# Altium schematic sheet RAMPS_TMC.SchDoc(RAMPS_TMC)
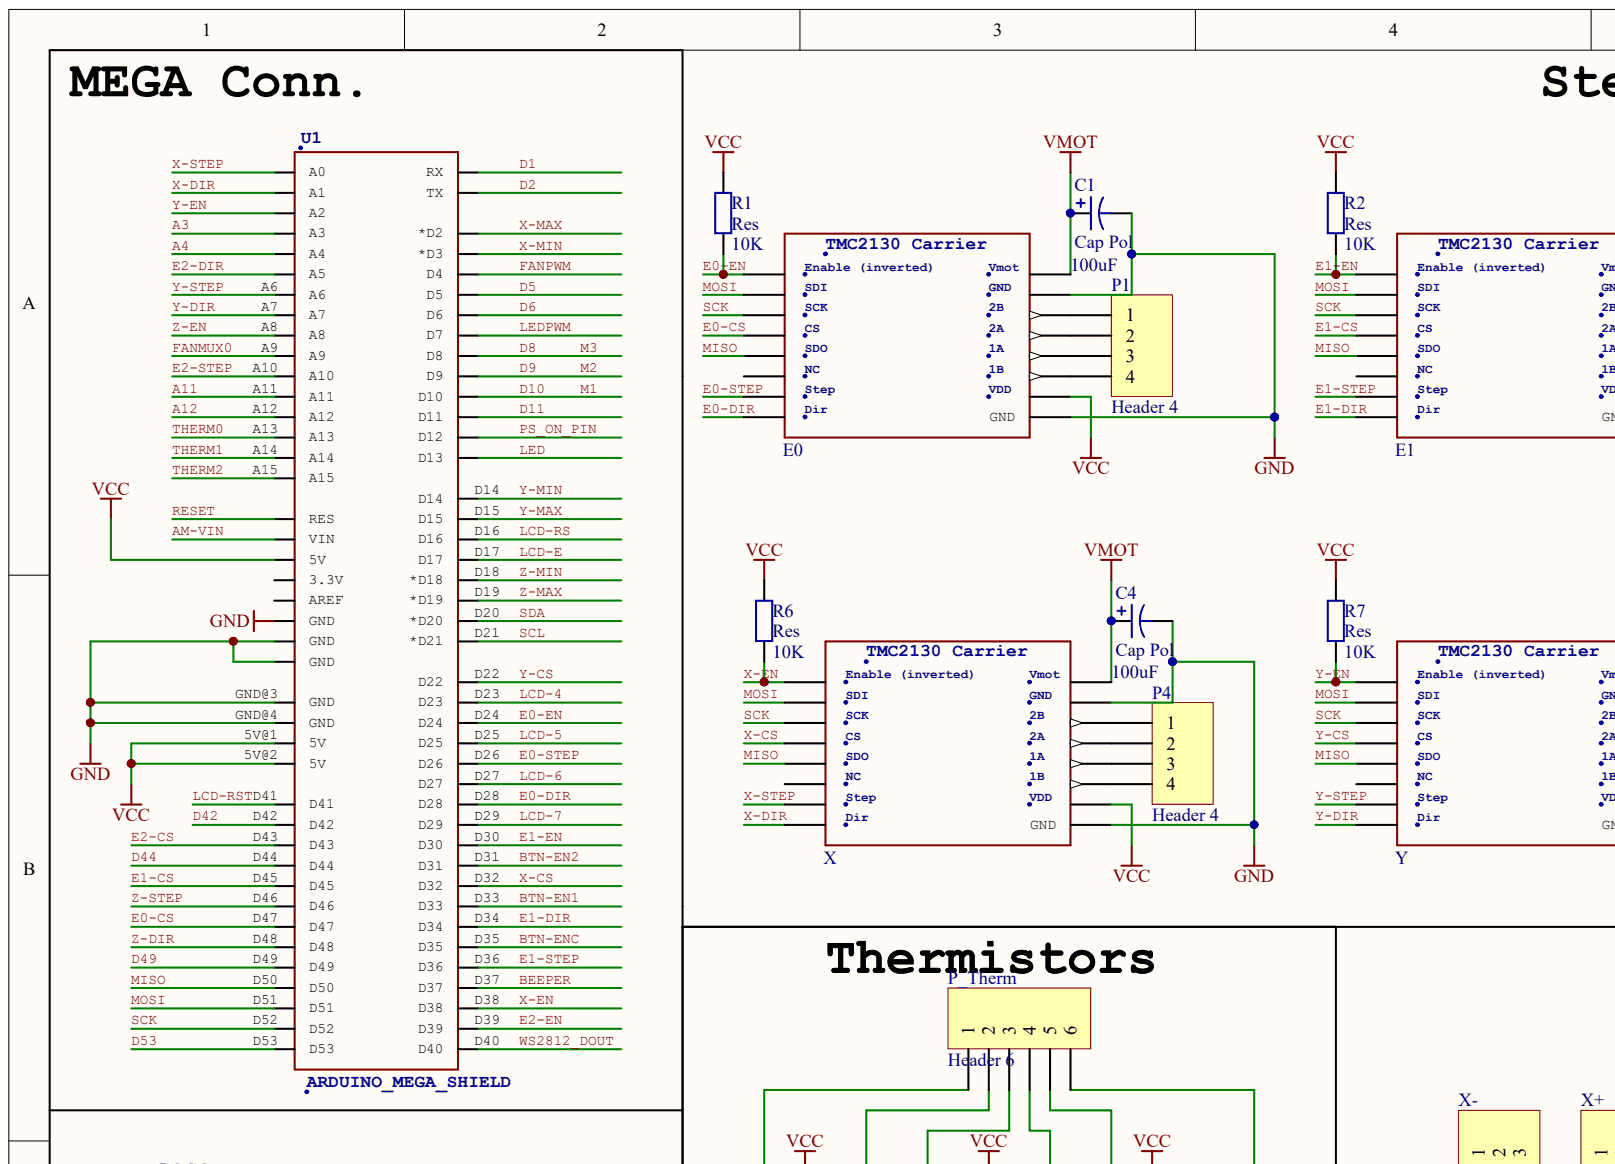
[diagram p0: RAMPS_TMC.SchDoc, top left]
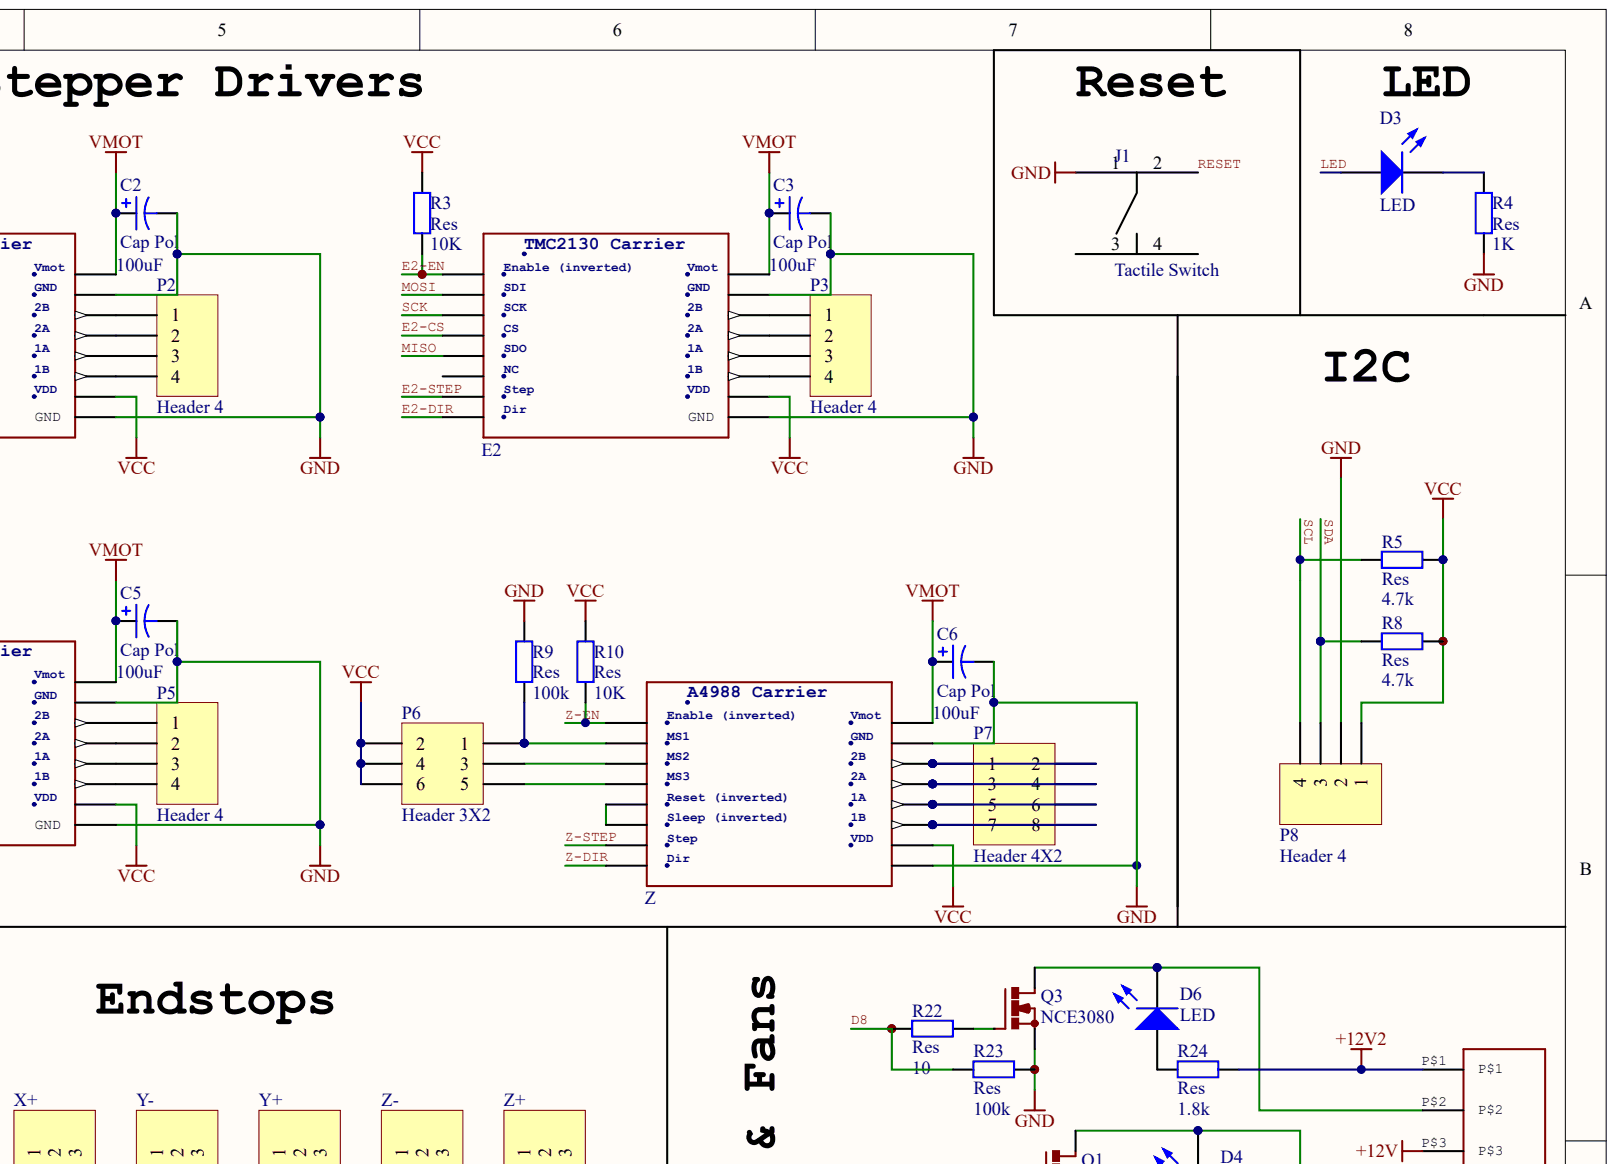
[diagram p1: RAMPS_TMC.SchDoc, top right]
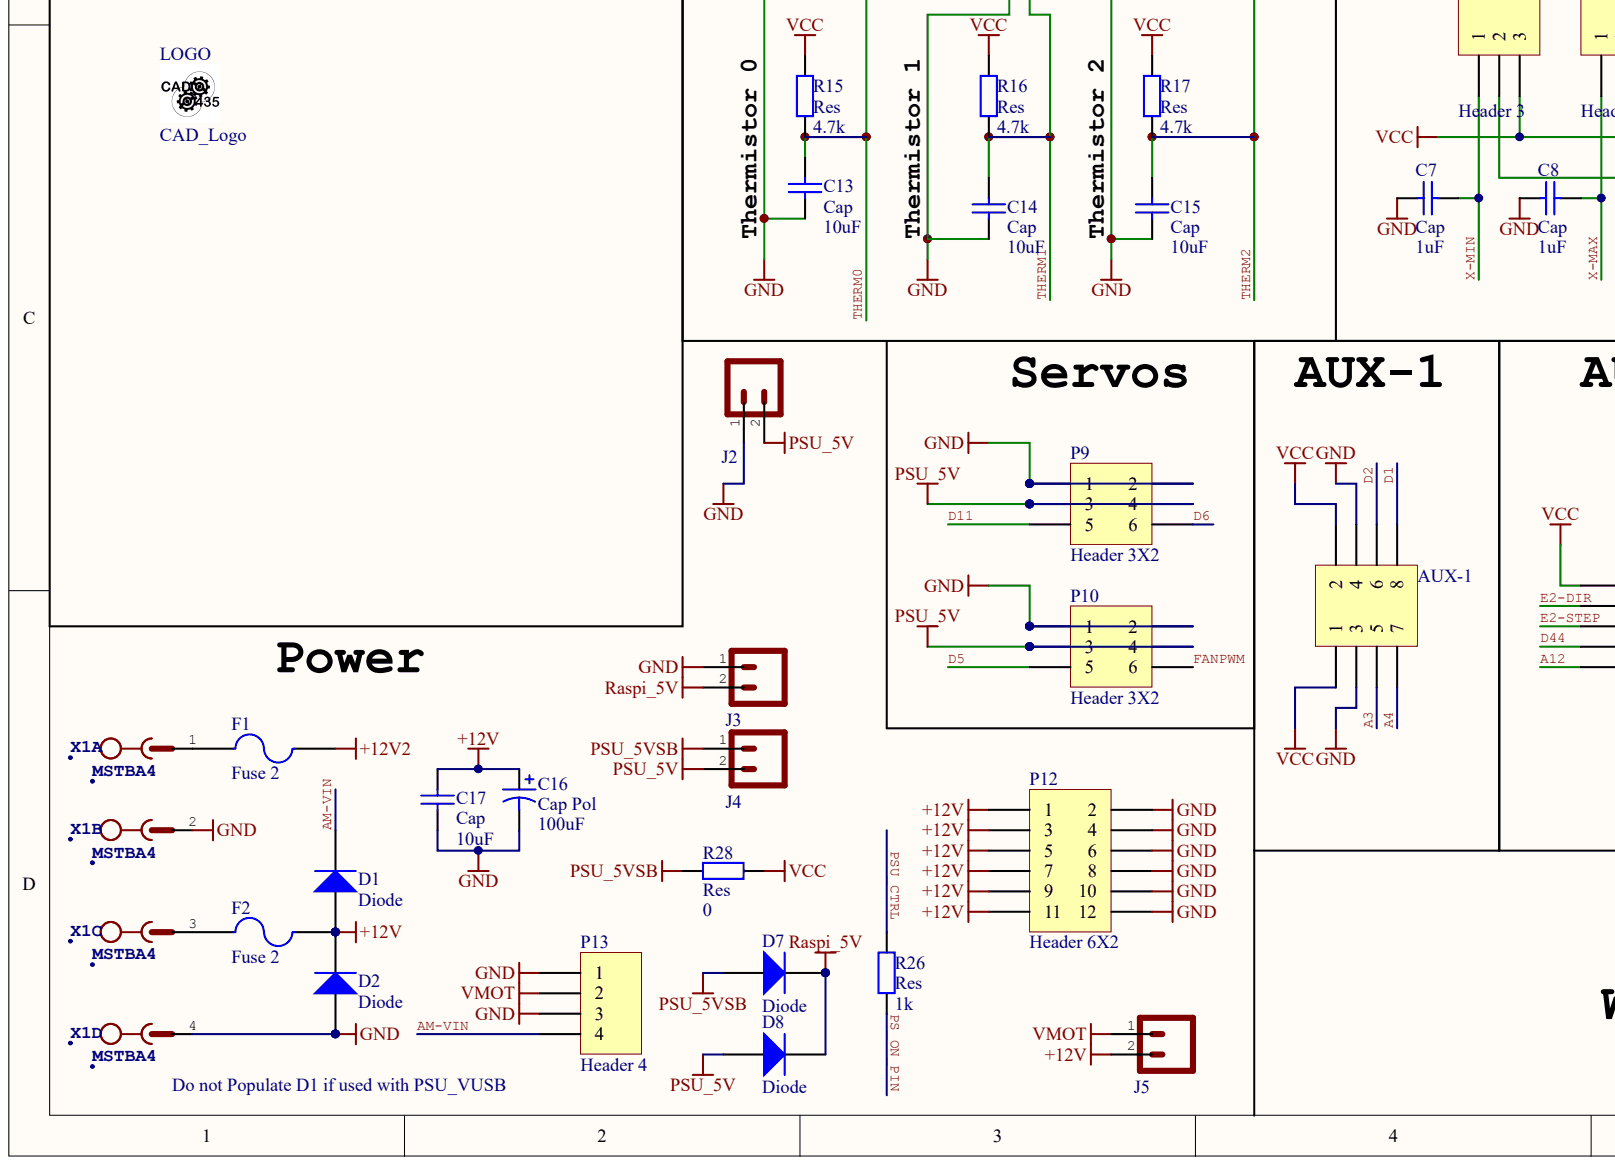
[diagram p2: RAMPS_TMC.SchDoc, bottom left]
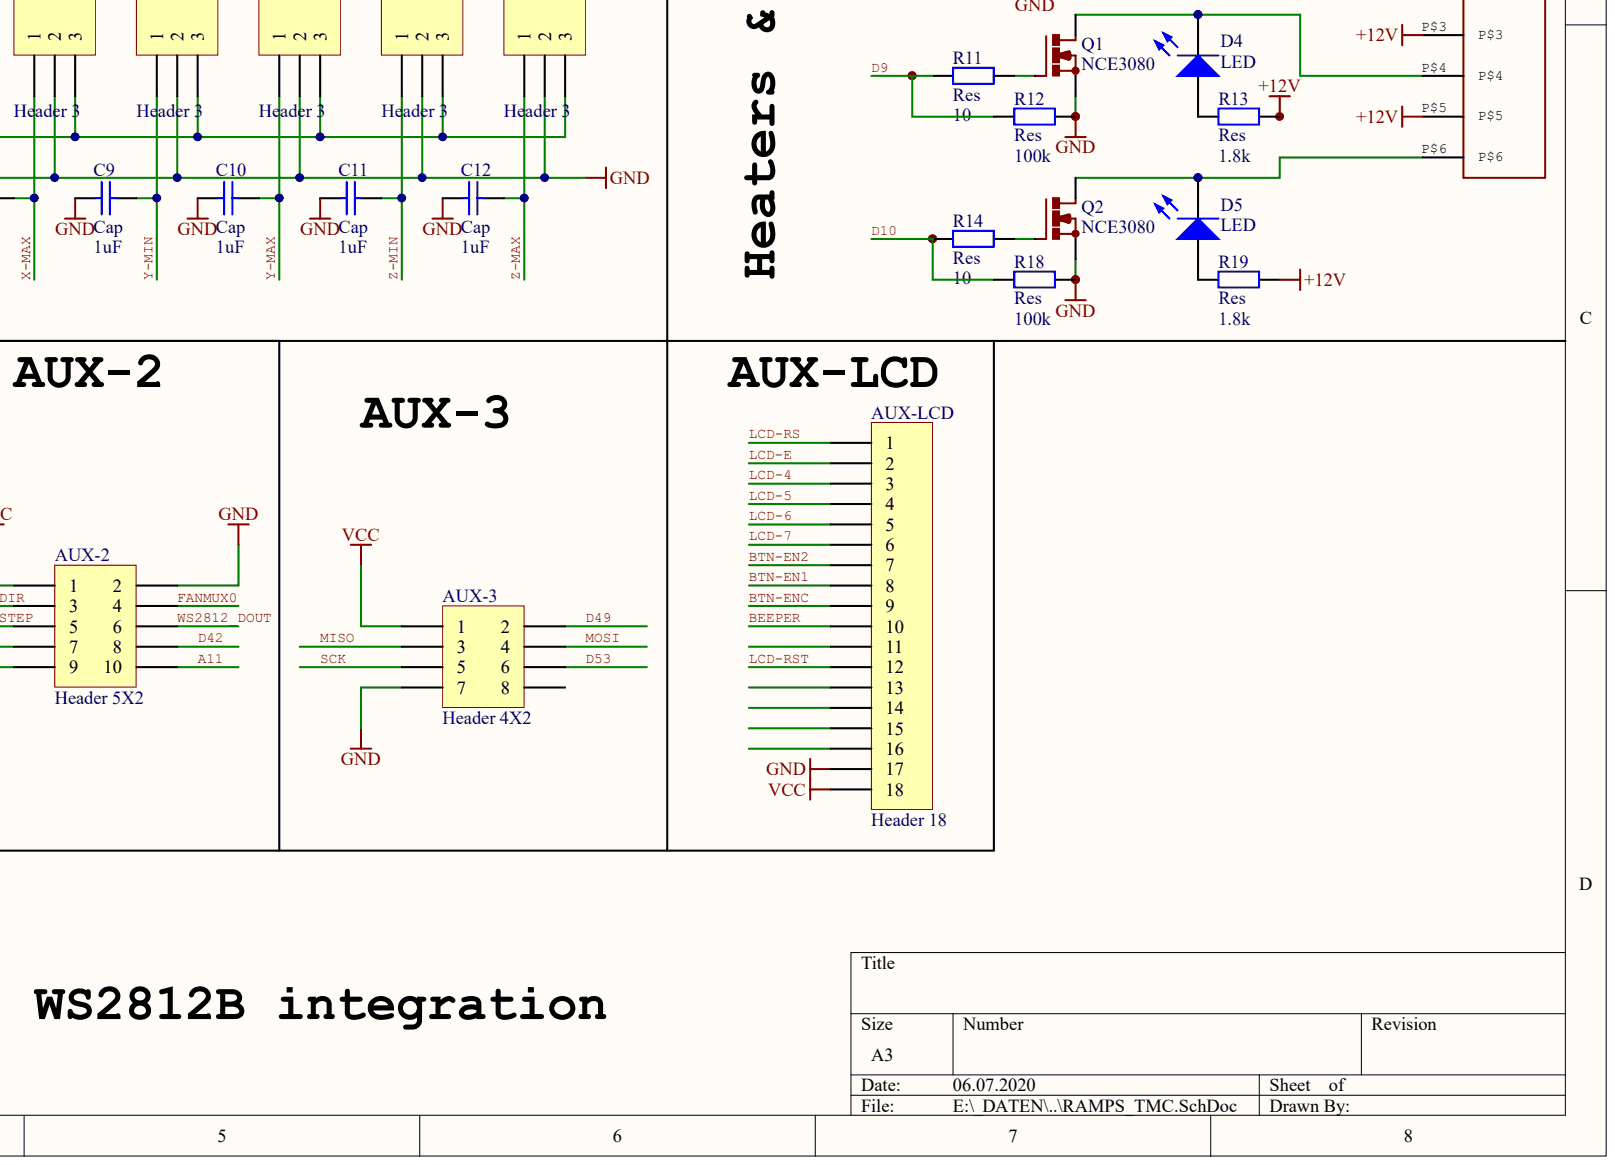
[diagram p3: RAMPS_TMC.SchDoc, bottom right]

## Parts
AUX-1  Header 4X2
AUX-2  Header 5X2
AUX-3  Header 4X2
AUX-LCD  Header 18
C1  Cap Pol  value 100uF
C2  Cap Pol  value 100uF
C3  Cap Pol  value 100uF
C4  Cap Pol  value 100uF
C5  Cap Pol  value 100uF
C6  Cap Pol  value 100uF
C7  Cap  value 1uF
C8  Cap  value 1uF
C9  Cap  value 1uF
C10  Cap  value 1uF
C11  Cap  value 1uF
C12  Cap  value 1uF
C13  Cap  value 10uF
C14  Cap  value 10uF
C15  Cap  value 10uF
C16  Cap Pol  value 100uF
C17  Cap  value 10uF
D1  Diode
D2  Diode
D3  LED
D4  LED
D5  LED
D6  LED
D7  Diode
D8  Diode
E0  MS1: SDI  MS2: SCK  MS3: CS  Reset (inverted): SDO  Sleep (inverted): NC  A4988 Carrier: TMC2130 Carrier  (hidden comment: TMC2130BREAKOUT)
E1  MS1: SDI  MS2: SCK  MS3: CS  Reset (inverted): SDO  Sleep (inverted): NC  A4988 Carrier: TMC2130 Carrier  (hidden comment: TMC2130BREAKOUT)
E2  MS1: SDI  MS2: SCK  MS3: CS  Reset (inverted): SDO  Sleep (inverted): NC  A4988 Carrier: TMC2130 Carrier  (hidden comment: TMC2130BREAKOUT)
F1  Fuse 2
F2  Fuse 2
J1  Tactile Switch
J2  Terminalblock X2  (value not drawn)
J3  Terminalblock X2  (value not drawn)
J4  Terminalblock X2  (value not drawn)
J5  Terminalblock X2  (value not drawn)
LOGO  CAD_Logo
P1  Header 4
P2  Header 4
P3  Header 4
P4  Header 4
P5  Header 4
P6  Header 3X2
P7  Header 4X2
P8  Header 4
P9  Header 3X2
P10  Header 3X2
P12  Header 6X2
P13  Header 4
P_Therm  Header 6
Q1  NCE3080
Q2  NCE3080
Q3  NCE3080
R1  Res  value 10K
R2  Res  value 10K
R3  Res  value 10K
R4  Res  value 1K
R5  Res  value 4.7k
R6  Res  value 10K
R7  Res  value 10K
R8  Res  value 4.7k
R9  Res  value 100k
R10  Res  value 10K
R11  Res  value 10
R12  Res  value 100k
R13  Res  value 1.8k
R14  Res  value 10
R15  Res  value 4.7k
R16  Res  value 4.7k
R17  Res  value 4.7k
R18  Res  value 100k
R19  Res  value 1.8k
R22  Res  value 10
R23  Res  value 100k
R24  Res  value 1.8k
R26  Res  value 1k
R28  Res  value 0
U1  ARDUINO_MEGA_SHIELD
U$1  282837-6  (value not drawn)
X  MS1: SDI  MS2: SCK  MS3: CS  Reset (inverted): SDO  Sleep (inverted): NC  A4988 Carrier: TMC2130 Carrier  (hidden comment: TMC2130BREAKOUT)
X1  MSTBA4  (parts A,B,C,D)
X+  Header 3
X-  Header 3
Y  MS1: SDI  MS2: SCK  MS3: CS  Reset (inverted): SDO  Sleep (inverted): NC  A4988 Carrier: TMC2130 Carrier  (hidden comment: TMC2130BREAKOUT)
Y+  Header 3
Y-  Header 3
Z  A4988BREAKOUT  (value not drawn)
Z+  Header 3
Z-  Header 3

## Nets
+12V: C16.2 C17.2 D1.2 D2.1 F2.1 J5.2 P12.1 P12.3 P12.5 P12.7 P12.9 P12.11 R13.2 R19.2 U$1.P$3 U$1.P$5
+12V2: F1.1 R24.2 U$1.P$1
GND: AUX-1.3 AUX-1.4 AUX-2.2 AUX-3.7 AUX-LCD.17 C1.1 C2.1 C3.1 C4.1 C5.1 C6.1 C7.2 C8.2 C9.2 C10.2 C11.2 C12.2 C13.1 C14.1 C15.1 C16.1 C17.1 D2.2 E0.GND E0.GND@0(GND) E1.GND E1.GND@0(GND) E2.GND E2.GND@0(GND) J1.1 J2.1 J3.1 P8.2 P9.1 P9.2 P10.1 P10.2 P12.2 P12.4 P12.6 P12.8 P12.10 P12.12 P13.1 P13.3 P_Therm.1 P_Therm.3 P_Therm.5 Q1.3 Q2.3 Q3.3 R4.1 R9.2 R12.2 R18.2 R23.2 U1.GND@0(GND) U1.GND@1(GND) U1.GND@2(GND) U1.GND@3(GND) U1.GND@4(GND) X.GND X.GND@0(GND) X1.2 X1.4 X+.2 X-.2 Y.GND Y.GND@0(GND) Y+.2 Y-.2 Z.GND Z.GND@0 Z+.2 Z-.2
PSU_5V: D8.2 J2.2 J4.2 P9.3 P9.4 P10.3 P10.4
PSU_5VSB: D7.2 J4.1 R28.1
RASPI_5V: D7.1 D8.1 J3.2
VCC: AUX-1.1 AUX-1.2 AUX-2.1 AUX-3.1 AUX-LCD.18 E0.VDD E1.VDD E2.VDD P6.2 P6.4 P6.6 P8.1 R1.2 R2.2 R3.2 R5.2 R6.2 R7.2 R8.2 R10.2 R15.2 R16.2 R17.2 R28.2 U1.5V@0(5V) U1.5V@1(5V) U1.5V@2(5V) X.VDD X+.3 X-.3 Y.VDD Y+.3 Y-.3 Z.VDD Z+.3 Z-.3
VMOT: C1.2 C2.2 C3.2 C4.2 C5.2 C6.2 E0.VMOT E1.VMOT E2.VMOT J5.1 P13.2 X.VMOT Y.VMOT Z.VMOT
A3: AUX-1.5 U1.A3
A4: AUX-1.7 U1.A4
A11: AUX-2.10 U1.A11
A12: AUX-2.9 U1.A12
AM-VIN: D1.1 P13.4 U1.VIN
BEEPER: AUX-LCD.10 U1.D37
BTN-EN1: AUX-LCD.8 U1.D33
BTN-EN2: AUX-LCD.7 U1.D31
BTN-ENC: AUX-LCD.9 U1.D35
D1: AUX-1.8 U1.RX
D2: AUX-1.6 U1.TX
D5: P10.5 U1.D5
D6: P9.6 U1.D6
D8: R22.1 R23.1 U1.D8
D9: R11.1 R12.1 U1.D9
D10: R14.1 R18.1 U1.D10
D11: P9.5 U1.D11
D42: AUX-2.8 U1.D42
D44: AUX-2.7 U1.D44
D49: AUX-3.2 U1.D49
D53: AUX-3.6 U1.D53
E0-CS: E0.MS3 U1.D47
E0-DIR: E0.DIR(Dir) U1.D28
E0-EN: E0.EN R1.1 U1.D24
E0-STEP: E0.STEP(Step) U1.D26
E1-CS: E1.MS3 U1.D45
E1-DIR: E1.DIR(Dir) U1.D34
E1-EN: E1.EN R2.1 U1.D30
E1-STEP: E1.STEP(Step) U1.D36
E2-CS: E2.MS3 U1.D43
E2-DIR: AUX-2.3 E2.DIR(Dir) U1.A5
E2-EN: E2.EN R3.1 U1.D39
E2-STEP: AUX-2.5 E2.STEP(Step) U1.A10
FANMUX0: AUX-2.4 U1.A9
FANPWM: P10.6 U1.D4
LCD-4: AUX-LCD.3 U1.D23
LCD-5: AUX-LCD.4 U1.D25
LCD-6: AUX-LCD.5 U1.D27
LCD-7: AUX-LCD.6 U1.D29
LCD-E: AUX-LCD.2 U1.D17
LCD-RS: AUX-LCD.1 U1.D16
LCD-RST: AUX-LCD.12 U1.D41
LED: D3.2 U1.D13
LEDPWM: U1.D7
MISO: AUX-3.3 E0.RST E1.RST E2.RST U1.D50 X.RST Y.RST
MOSI: AUX-3.4 E0.MS1 E1.MS1 E2.MS1 U1.D51 X.MS1 Y.MS1
PS_ON_PIN: R26.1 U1.D12
PSU_CTRL: R26.2
RESET: J1.2 U1.RES
SCK: AUX-3.5 E0.MS2 E1.MS2 E2.MS2 U1.D52 X.MS2 Y.MS2
SCL: P8.4 R5.1 U1.D21(*D21)
SDA: P8.3 R8.1 U1.D20(*D20)
THERM0: C13.2 P_Therm.2 R15.1 U1.A13
THERM1: C14.2 P_Therm.4 R16.1 U1.A14
THERM2: C15.2 P_Therm.6 R17.1 U1.A15
WS2812_DOUT: AUX-2.6 U1.D40
X-CS: U1.D32 X.MS3
X-DIR: U1.A1 X.DIR(Dir)
X-EN: R6.1 U1.D38 X.EN
X-MAX: C8.1 U1.D2(*D2) X+.1
X-MIN: C7.1 U1.D3(*D3) X-.1
X-STEP: U1.A0 X.STEP(Step)
Y-CS: U1.D22 Y.MS3
Y-DIR: U1.A7 Y.DIR(Dir)
Y-EN: R7.1 U1.A2 Y.EN
Y-MAX: C10.1 U1.D15 Y+.1
Y-MIN: C9.1 U1.D14 Y-.1
Y-STEP: U1.A6 Y.STEP(Step)
Z-DIR: U1.D48 Z.DIR
Z-EN: R10.1 U1.A8 Z.EN
Z-MAX: C12.1 U1.D19(*D19) Z+.1
Z-MIN: C11.1 U1.D18(*D18) Z-.1
Z-STEP: U1.D46 Z.STEP
NetD3_1: D3.1 R4.2
NetD4_1: D4.1 Q1.2 U$1.P$4
NetD4_2: D4.2 R13.1
NetD5_1: D5.1 Q2.2 U$1.P$6
NetD5_2: D5.2 R19.1
NetD6_1: D6.1 Q3.2 U$1.P$2
NetD6_2: D6.2 R24.1
NetE0_1A: E0.1A P1.3
NetE0_1B: E0.1B P1.4
NetE0_2A: E0.2A P1.2
NetE0_2B: E0.2B P1.1
NetE1_1A: E1.1A P2.3
NetE1_1B: E1.1B P2.4
NetE1_2A: E1.2A P2.2
NetE1_2B: E1.2B P2.1
NetE2_1A: E2.1A P3.3
NetE2_1B: E2.1B P3.4
NetE2_2A: E2.2A P3.2
NetE2_2B: E2.2B P3.1
NetF1_2: F1.2 X1.1
NetF2_2: F2.2 X1.3
NetP4_1: P4.1 X.2B
NetP4_2: P4.2 X.2A
NetP4_3: P4.3 X.1A
NetP4_4: P4.4 X.1B
NetP5_1: P5.1 Y.2B
NetP5_2: P5.2 Y.2A
NetP5_3: P5.3 Y.1A
NetP5_4: P5.4 Y.1B
NetP6_1: P6.1 R9.1 Z.MS1
NetP6_3: P6.3 Z.MS2
NetP6_5: P6.5 Z.MS3
NetP7_1: P7.1 P7.2 Z.2B
NetP7_3: P7.3 P7.4 Z.2A
NetP7_5: P7.5 P7.6 Z.1A
NetP7_7: P7.7 P7.8 Z.1B
NetQ1_1: Q1.1 R11.2
NetQ2_1: Q2.1 R14.2
NetQ3_1: Q3.1 R22.2
NetZ_RST: Z.RST(Reset) Z.SLP(Sleep)

Not connected: AUX-3 8; AUX-LCD 11 13 14 15 16; E0 SLP(NC); E1 SLP(NC); E2 SLP(NC); J1 3 4; U1 3.3V AREF; X SLP; Y SLP

## Library data of the parts
C1-C6 = (no symbol name): Value: 100uF; Published: 8-Jun-2000; LatestRevisionDate: 17-Jul-2002; LatestRevisionNote: Re-released for DXP Platform.; PackageReference: POLAR0.8; PackageDescription: Polarized Capacitor; 2 Leads; Publisher: Altium Limited
D1-D8 = (no symbol name): PCB_Layout: Complies with manufacturer's recommendation.; Published: 14-Mar-2008; DatasheetVersion: Mar-2007; PackageVersion: Mar-2007; PackageReference: LWP4SG; PackageDescription: White LED; 2 Reverse Gullwing Leads, Body 1.9 x 0.875mm (Dia.xH); ComponentLink1Description: Manufacturer Link; ComponentLink1URL: http://www.osram-os.com/; ComponentLink2Description: Datasheet; ComponentLink2URL: http://catalog.osram-os.com/catalogue/catalogue.do;jsessionid=AD571800CED0208623E7FAFDF556DB1C?act=downloadFile&favOid=020000030001e106000200b6; Publisher: Altium Limited
J1 = (no symbol name): Value: 1K; Published: 8-Jun-2000; LatestRevisionDate: 17-Jul-2002; LatestRevisionNote: Re-released for DXP Platform.; PackageReference: AXIAL-0.4; PackageDescription: Axial Device, Thru-Hole; 2 Leads; 0.4 in Pin Spacing; Publisher: Altium Limited
Q1-Q3 = (no symbol name): Note: Pin 2 is electrically connected to pin 4.; Published: 8-Jun-2000; LatestRevisionDate: 17-Jul-2002; LatestRevisionNote: Re-released for DXP Platform.; PackageReference: TO-251AA; PackageDescription: TO, Thru-Hole, Vertical, Heatsink Mounted; 3 In-Line Leads; Pitch 2.28 mm; ComponentLink1Description: Manufacturer Link; ComponentLink1URL: http://www.irf.com/; ComponentLink2Description: Datasheet; ComponentLink2URL: http://www.irf.com/product-info/datasheets/data/irlr024n.pdf; Publisher: Altium Limited
R5 = (no symbol name): Value: 4.7k; Published: 8-Jun-2000; LatestRevisionDate: 17-Jul-2002; LatestRevisionNote: Re-released for DXP Platform.; PackageReference: AXIAL-0.4; PackageDescription: Axial Device, Thru-Hole; 2 Leads; 0.4 in Pin Spacing; Publisher: Altium Limited
R12 = (no symbol name): Value: 100k; Published: 8-Jun-2000; LatestRevisionDate: 17-Jul-2002; LatestRevisionNote: Re-released for DXP Platform.; PackageReference: AXIAL-0.4; PackageDescription: Axial Device, Thru-Hole; 2 Leads; 0.4 in Pin Spacing; Publisher: Altium Limited
R13 R24 = (no symbol name): Value: 1.8k; Published: 8-Jun-2000; LatestRevisionDate: 17-Jul-2002; LatestRevisionNote: Re-released for DXP Platform.; PackageReference: AXIAL-0.4; PackageDescription: Axial Device, Thru-Hole; 2 Leads; 0.4 in Pin Spacing; Publisher: Altium Limited
AUX-1-AUX-3 AUX-LCD C7-C17 E0-E2 F1 F2 J2-J5 LOGO P1-P10 P12 P13 P_Therm R1-R4 R6-R11 R14-R19 R22 R23 R26 R28 U1 U$1 X X1 X+ X- Y Y+ Y- Z Z+ Z- = (no symbol name)
  AUX-1-AUX-3 AUX-LCD P1-P10 P12 P13 P_Therm X+ X- Y+ Y- Z+ Z-: LatestRevisionDate: 17-Jul-2002; LatestRevisionNote: Re-released for DXP Platform.
  C7-C15 C17: Published: 8-Jun-2000; LatestRevisionDate: 17-Jul-2002; LatestRevisionNote: Re-released for DXP Platform.; PackageReference: RAD-0.3; PackageDescription: Radial Cap, Thru-Hole; 2 Leads; 0.3 in Pin Spacing
  C16: Published: 8-Jun-2000; LatestRevisionDate: 17-Jul-2002; LatestRevisionNote: Re-released for DXP Platform.; PackageReference: POLAR0.8; PackageDescription: Polarized Capacitor; 2 Leads
  E0-E2 X Y: GND: GND; Enable (inverted): Enable (inverted); Step: Step; Dir: Dir; VDD: VDD; Vmot: Vmot; 2B: 2B; 2A: 2A; 1A: 1A; 1B: 1B
  F1 F2: Published: 8-Jun-2000; LatestRevisionDate: 17-Jul-2002; LatestRevisionNote: Re-released for DXP Platform.; PackageReference: PIN-W2/E2.8; PackageDescription: Thru-Hole; 2 Leads
  R1-R4 R6-R11 R14-R19 R22 R23 R26 R28: Published: 8-Jun-2000; LatestRevisionDate: 17-Jul-2002; LatestRevisionNote: Re-released for DXP Platform.; PackageReference: AXIAL-0.4; PackageDescription: Axial Device, Thru-Hole; 2 Leads; 0.4 in Pin Spacing
  Z: GND: GND; Enable (inverted): Enable (inverted); MS1: MS1; MS2: MS2; MS3: MS3; Reset (inverted): Reset (inverted); Sleep (inverted): Sleep (inverted); Step: Step; Dir: Dir; VDD: VDD; Vmot: Vmot; 2B: 2B; 2A: 2A; 1A: 1A; 1B: 1B; A4988 Carrier: A4988 Carrier
  AUX-1-AUX-3 AUX-LCD C7-C17 F1 F2 P1-P10 P12 P13 P_Therm R1-R4 R6-R11 R14-R19 R22 R23 R26 R28 X+ X- Y+ Y- Z+ Z-: Publisher: Altium Limited
